# Altium schematic sheet Power_External_Connectors.SchDoc(Power_External_Connectors)
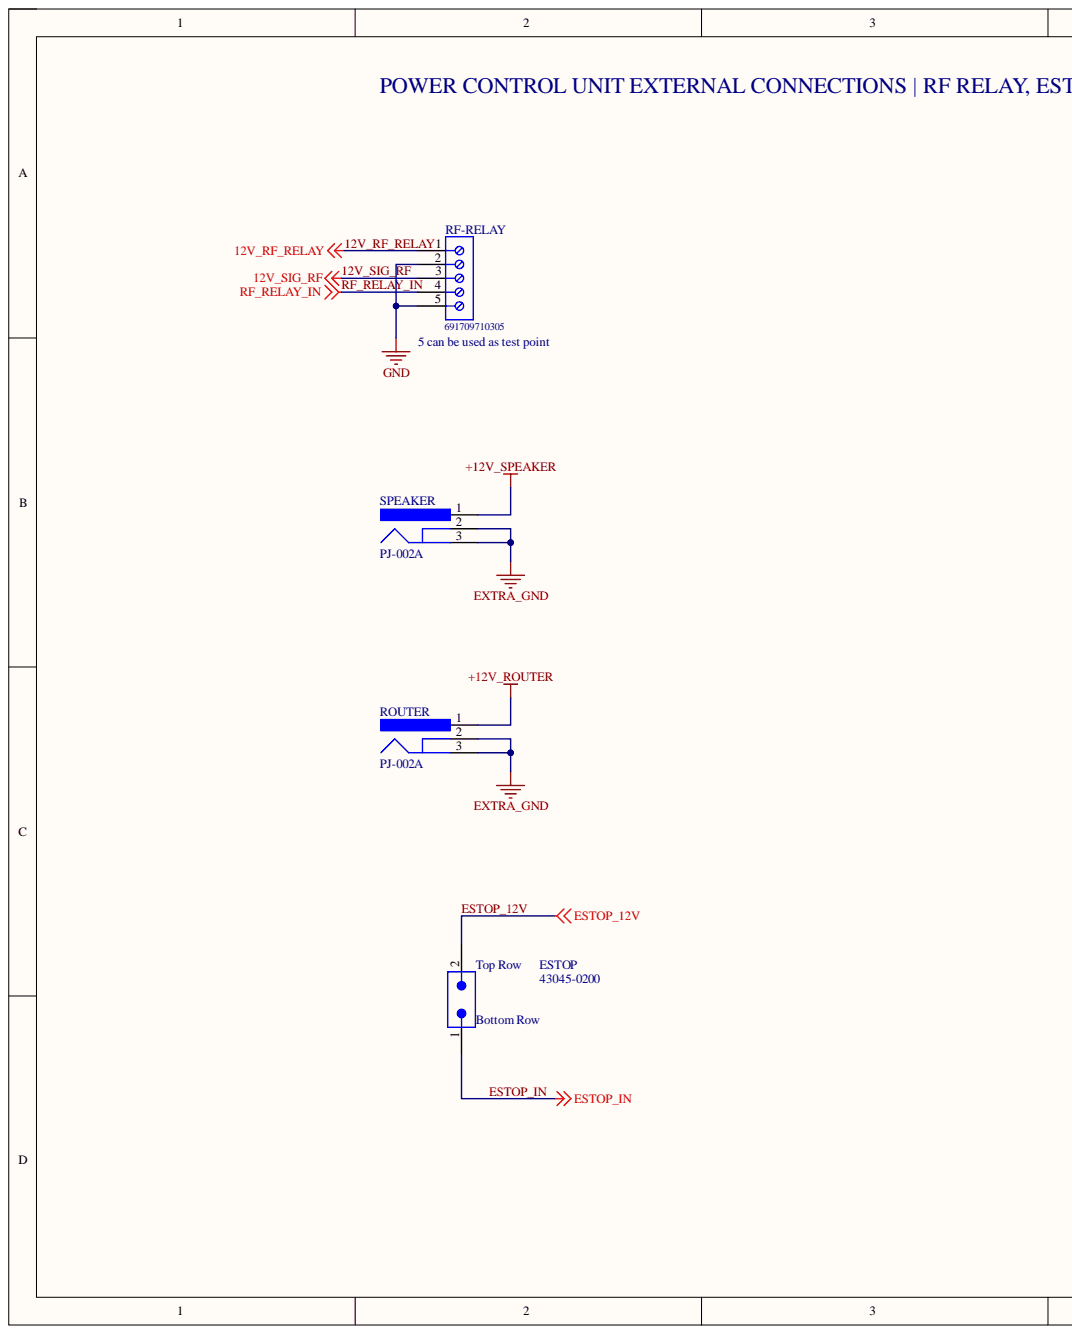
[diagram p0: Power_External_Connectors.SchDoc, left]
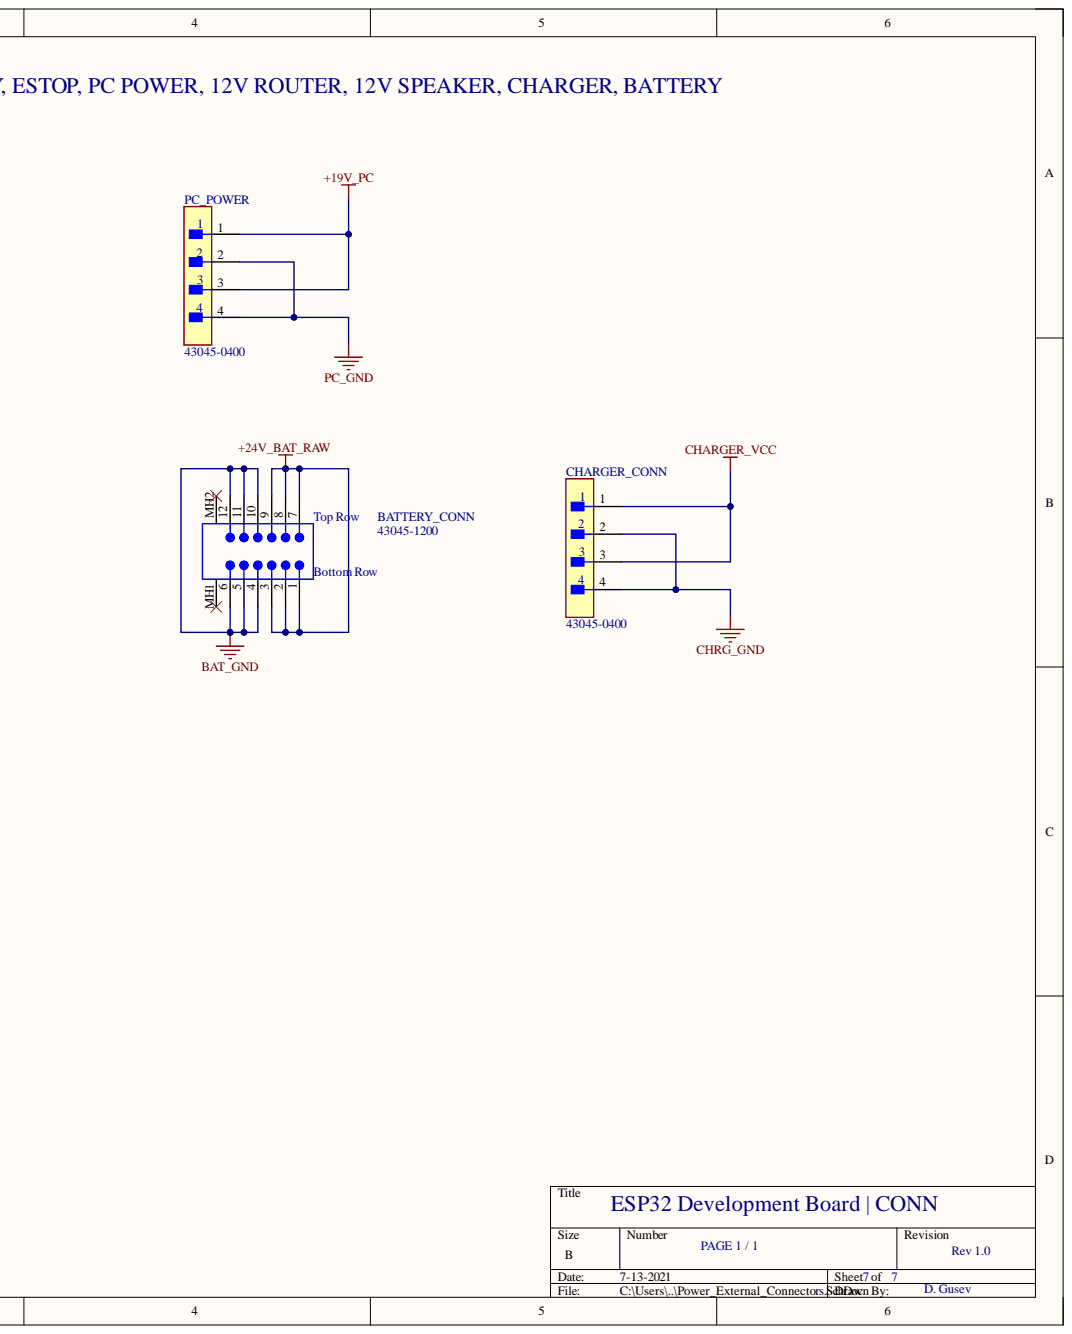
[diagram p1: Power_External_Connectors.SchDoc, right]

## Parts
BATTERY_CONN  43045-1200  [MOLX-43045-1200]
CHARGER_CONN  43045-0400  [FP-43045-0400-MFG]
ESTOP  43045-0200  [MOLX-43045-0200]
PC_POWER  43045-0400  [FP-43045-0400-MFG]
RF-RELAY  691709710305  [691709710305]
ROUTER  PJ-002A  [CUI-PJ-002A_V]
SPEAKER  PJ-002A  [CUI-PJ-002A_V]

## Nets
+19V_PC: PC_POWER.1 PC_POWER.3
+24V_BAT_RAW: BATTERY_CONN.1 BATTERY_CONN.2 BATTERY_CONN.3 BATTERY_CONN.7 BATTERY_CONN.8 BATTERY_CONN.9
BAT_GND: BATTERY_CONN.4 BATTERY_CONN.5 BATTERY_CONN.6 BATTERY_CONN.10 BATTERY_CONN.11 BATTERY_CONN.12
CHARGER_VCC: CHARGER_CONN.1 CHARGER_CONN.3
CHRG_GND: CHARGER_CONN.2 CHARGER_CONN.4
EXTRA_GND: ROUTER.2 ROUTER.3 SPEAKER.2 SPEAKER.3
GND: RF-RELAY.2 RF-RELAY.5
PC_GND: PC_POWER.2 PC_POWER.4
12V_RF_RELAY: RF-RELAY.1 ROUTER.1 SPEAKER.1
12V_SIG_RF: RF-RELAY.3
ESTOP_12V: ESTOP.2
ESTOP_IN: ESTOP.1
RF_RELAY_IN: RF-RELAY.4

Not connected: BATTERY_CONN MH1 MH2

## Library data of the parts
BATTERY_CONN = Molex 0430451-200: 3 mm Pitch Micro-Fit 3.0 Connector, 17.27 mm Mated Height, Vertical, Through Hole, 12 Circuits, -40 to 105 degC, RoHS, Tray; PartNumber: 43045-0812; No. of Positions: 8; No. of Rows: 2; Pitch: 3 mm; Component Kind: Standard; ComponentLink1Description: Manufacturer URL; ComponentLink1URL: http://www.molex.com/; ComponentLink2Description: Datasheet; ComponentLink2URL: http://www.molex.com/webdocs/datasheets/pdf/en-us/0430450812_PCB_HEADERS.pdf; ComponentLink3Description: Package Specification; ComponentLink3URL: http://www.molex.com/pdm_docs/sd/430450812_sd.pdf; Mounting Technology: Through Hole; Orientation: Vertical; PackageDescription: 8-Pin PCB Header, Vertical, Pitch 3 mm, Mated Height 17.27 mm, Through Hole; PackageVersion: Rev. F, 06/2009; Packing: Tray; RoHS: TRUE; Temperature Max: 105 degC; Temperature Min: -40 degC; Library Name: ANTS-MotherBoard-B1.SchLib
CHARGER_CONN PC_POWER = CMP-04776-000002-1: CONN HEADER R/A 4POS 3MM; Manufacturer: Molex; Conn Gender: Male; Conn Orientation: Right Angle; Current Rating: 8.5A; Is Female: yes; Number Of Contacts: 4; Voltage Rating: 600V; Automotive: No; Category: Conn; Component Kind: Standard; ComponentLink2Description: Datasheet; ComponentLink2URL: https://www.molex.com/webdocs/datasheets/pdf/en-us/0430450400_PCB_HEADERS.pdf; Contact Material: Tin,Nickel; Device Class L1: Connectors; Device Class L2: Headers and Wire Housings; Device Class L3: unset; Digikey Description: CONN HEADER R/A 4POS 3MM; Footprint Url: https://www.molex.com/pdm_docs/sd/430450400_sd.pdf; Height: 9.27mm; Lead Free: Yes; Library Name: Altium Content Vault; Manufacturer Part Number: 43045-0400; Number Of Rows: 2; Octopart: https://octopart.com/43045-0400-molex-287063; Package: 43045-0400; Pitch: 3mm; RoHS: TRUE; Temperature: -40°C to +105°C; Temperature Range High: +105°C; Temperature Range Low: -40°C
ESTOP = Molex 0430450-200: 3 mm Pitch Micro-Fit 3.0 Connector, 17.27 mm Mated Height, Vertical, Through Hole, 2 Circuits, -40 to 105 degC, RoHS, Tray; PartNumber: 43045-0812; No. of Positions: 8; No. of Rows: 2; Pitch: 3 mm; Component Kind: Standard; ComponentLink1Description: Manufacturer URL; ComponentLink1URL: http://www.molex.com/; ComponentLink2Description: Datasheet; ComponentLink2URL: http://www.molex.com/webdocs/datasheets/pdf/en-us/0430450812_PCB_HEADERS.pdf; ComponentLink3Description: Package Specification; ComponentLink3URL: http://www.molex.com/pdm_docs/sd/430450812_sd.pdf; Mounting Technology: Through Hole; Orientation: Vertical; PackageDescription: 8-Pin PCB Header, Vertical, Pitch 3 mm, Mated Height 17.27 mm, Through Hole; PackageVersion: Rev. F, 06/2009; Packing: Tray; RoHS: TRUE; Temperature Max: 105 degC; Temperature Min: -40 degC; Library Name: ANTS-MotherBoard-B1.SchLib
RF-RELAY = CMP-1502-03338-1: Serie 7097 - 5.00 mm Horizontal Entry SMT with Pressure Clamp WR-TBL, 5 pin; Manufacturer: Wurth Elektronik; Pin Number: 5; Pitch: 5.00mm; Component Kind: Standard; Datasheet URL: http://katalog.we-online.de/em/datasheet/6917097103xx.pdf; Library Name: Altium Content Vault; Manufacturer URL: http://www.we-online.de; Mounting Technology: SMT; Operating Temperature: -45to130degC; Package Reference: 691709710305; Packaging: TapeandReelwithCap; Part Number: 691709710305; Publisher: WurthElektronik
ROUTER SPEAKER = CMP-2000-06895-1: Through Hole Right Angle DC Power Jack, 2.5 A, 2 mm Center Pin, 3 Position, -25 to 85 degC, RoHS, Bulk; Manufacturer: CUIInc.; Brand: CUI; Current Rating: 2.5A; Inside Contact Diameter: 2mm; Insulation Resistance: 50MOhms; Outside Contact Diameter: 6.5mm; Voltage Rating: 24V; Component Kind: Standard; Connector Type: DCPowerJack; Contact Gender: Male; Contact Material: Copper; Contact Plating: Nickel; Datasheet URL: http://www.cui.com/product/resource/pj-002a.pdf; Datasheet Version: Rev. 1.03, 04/2016; Factory Pack Quantity: 500; Flammability Rating: UL94V-0; Housing Material: PolybutyleneTerephthalate(PBT); Library Name: Altium Content Vault; Manufacturer URL: http://www.cui.com/; Mounting Angle: Right; Mounting Style: ThroughHole; Mounting Technology: ThroughHole; Operating Temperature Range: -25Cto+85C; Package Description: 3-Pin Through Hole Device, Body 14.4 x 9 mm, Height 11 mm; Package Reference: PJ-002A; Product: Jacks; Product Category: DCPowerConnectors; RoHS: True; Termination Style: ThroughHole
